# Altium schematic sheet WifiDB.SchDoc
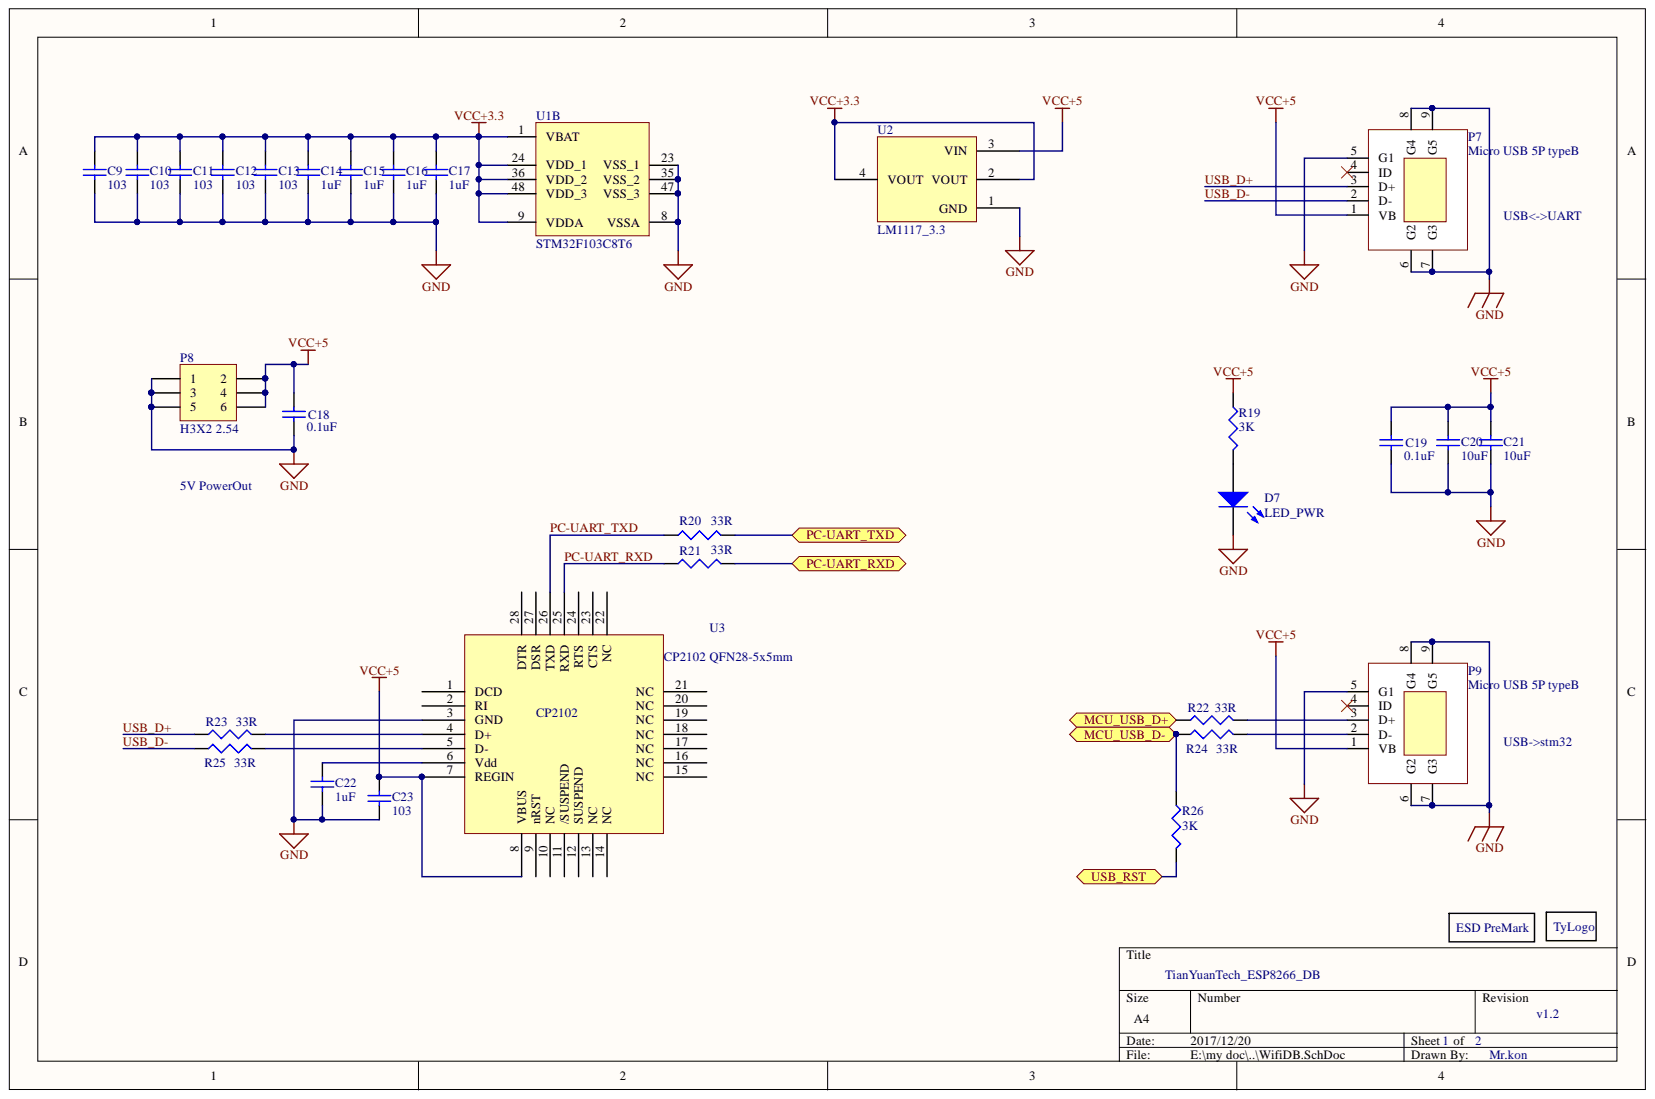
[diagram p0: WifiDB.SchDoc]

## Parts
C9  103  [1608[0603]]
C10  103  [1608[0603]]
C11  103  [1608[0603]]
C12  103  [1608[0603]]
C13  103  [1608[0603]]
C14  1uF  [1608[0603]]
C15  1uF  [1608[0603]]
C16  1uF  [1608[0603]]
C17  1uF  [1608[0603]]
C18  0.1uF  [1608[0603]]
C19  0.1uF  [1608[0603]]
C20  10uF  [1608[0603]]
C21  10uF  [1608[0603]]
C22  1uF  [1608[0603]]
C23  103  [1608[0603]]
D7  LED_PWR  [LED0805]
lo1  tylogo  [tianyuanlogo]  (value not drawn)
Logo1  ESD protect mark  [ESD_MARK]  (value not drawn)
P7  Micro USB 5P typeB  [Micro usb 5P/FB Type dip]
P8  H3X2 2.54  [HDR2X3]
P9  Micro USB 5P typeB  [Micro usb 5P/FB Type dip]
R19  value 3K  [J1-0603]  (hidden comment: Res3)
R20  value 33R  [J1-0603]  (hidden comment: Res3)
R21  value 33R  [J1-0603]  (hidden comment: Res3)
R22  value 33R  [J1-0603]  (hidden comment: Res3)
R23  value 33R  [J1-0603]  (hidden comment: Res3)
R24  value 33R  [J1-0603]  (hidden comment: Res3)
R25  value 33R  [J1-0603]  (hidden comment: Res3)
R26  value 3K  [J1-0603]  (hidden comment: Res3)
U1  STM32F103C8T6  [STM-LQFP48_N]  (part B)
U2  LM1117_3.3  [SOT230P695X180-4N]
U3  CP2102 QFN28-5x5mm  [QFN28-5x5mm]

## Ports
MCU_USB_D+, MCU_USB_D-, PC-UART_RXD, PC-UART_TXD, USB_RST

## Nets
GND: C9.1 C10.1 C11.1 C12.1 C13.1 C14.1 C15.1 C16.1 C17.1 C18.1 C19.1 C20.1 C21.1 C22.1 C23.1 D7.2 P7.5(G1) P7.6(G2) P7.7(G3) P7.8(G4) P7.9(G5) P8.1 P8.3 P8.5 P9.5(G1) P9.6(G2) P9.7(G3) P9.8(G4) P9.9(G5) U1.8(VSSA) U1.23(VSS_1) U1.35(VSS_2) U1.47(VSS_3) U2.1(GND) U3.3(GND)
VCC+3.3: C9.2 C10.2 C11.2 C12.2 C13.2 C14.2 C15.2 C16.2 C17.2 U1.1(VBAT) U1.9(VDDA) U1.24(VDD_1) U1.36(VDD_2) U1.48(VDD_3) U2.2(VOUT) U2.4(VOUT)
VCC+5: C18.2 C19.2 C20.2 C21.2 C23.2 P7.1(VB) P8.2 P8.4 P8.6 P9.1(VB) R19.1 U2.3(VIN) U3.7(REGIN) U3.8(VBUS)
PC-UART_RXD: R21.1 U3.25(RXD)
PC-UART_TXD: R20.1 U3.26(TXD)
USB_D+: P7.3(D+) R23.1
USB_D-: P7.2(D-) R25.1
USB_RST: R26.2 ; port USB_RST
NetC22_2: C22.2 U3.6(Vdd)
NetD7_1: D7.1 R19.2
NetP9_2: P9.2(D-) R24.2
NetP9_3: P9.3(D+) R22.2
NetR20_2: R20.2 ; port PC-UART_TXD
NetR21_2: R21.2 ; port PC-UART_RXD
NetR22_1: R22.1
NetR23_2: R23.2 U3.4(D+)
NetR24_1: R24.1 R26.1
NetR25_2: R25.2 U3.5(D-)

Not connected: P7 4(ID); P9 4(ID); U3 1(DCD) 2(RI) 9(nRST) 10(NC) 11(/SUSPEND) 12(SUSPEND) 13(NC) 14(NC) 15(NC) 16(NC) 17(NC) 18(NC) 19(NC) 20(NC) 21(NC) 22(NC) 23(CTS) 24(RTS) 27(DSR) 28(DTR)

## Library data of the parts
C9-C23 = C 0603/25v: Component Kind: Standard; Library Name: mysch.SchLib
D7 = LED2: GREEN; Component Kind: Standard; LatestRevisionDate: 17-Jul-2002; LatestRevisionNote: Re-released for DXP Platform.; Library Name: Miscellaneous Devices.IntLib; PackageDescription: SM LED; 2 Flat Leads; PackageReference: 3.2X1.6X1.1; PackageVersion: Feb-2002; Published: 8-Jun-2000; Simulation: LED2; Publisher: Altium Limited
Logo1 = ESD PreMark: Component Kind: Standard; Library Name: New_Integrated_Library.IntLib
P7 P9 = USB 5P/F B Type: Micro or Mini; Component Kind: Standard; Library Name: New_Integrated_Library.IntLib
P8 = Header 3X2: Header, 3-Pin, Dual row; Component Kind: Standard; LatestRevisionDate: 17-Jul-2002; LatestRevisionNote: Re-released for DXP Platform.; Library Name: Miscellaneous Connectors.IntLib; Signal Integrity: Connector; Publisher: Altium Limited
R19-R26 = Res3: Resistor; Component Kind: Standard; LatestRevisionDate: 29-May-2009; LatestRevisionNote: IPC-7351 Footprint Added.; Library Name: Miscellaneous Devices.IntLib; PackageDescription: Chip Resistor; PackageReference: J1-0603; Published: 8-Jun-2000; Signal Integrity: Res; Simulation: RESISTOR; Publisher: Altium Limited
U1 = STM32F103C8T6: ARM Cortex-M3 32-bit MCU, 64 KB Flash, 20 KB Internal RAM, 37 I/Os, 48-pin LQFP, -40 to 85 degC, Tray; A/D Converter: 2x12-bit (10 Channel); FLASH Size (Prog) (kB): 64; I/Os (High Current): 37; Internal RAM Size (kB): 20; Operating Frequency(F) (Processor speed) (MHz): 72; Supply Voltage(Vcc) min (V): 2; Component Kind: Standard; ComponentLink1Description: Manufacturer URL; ComponentLink1URL: http://www.st.com/web/en/home.html; ComponentLink2Description: Datasheet; ComponentLink2URL: http://www.st.com/st-web-ui/static/active/en/resource/technical/document/datasheet/CD00161566.pdf; ComponentLink3Description: Package Specification; ComponentLink3URL: http://www.st.com/st-web-ui/static/active/en/resource/technical/document/datasheet/DM00058181.pdf; Core: ARM Cortex-M3; DatasheetVersion: Rev. 16; Library Name: STMicroelectronics STM32 F1.IntLib; Mounting Technology: Surface Mount; PackageDescription: 48-Pin Low Profile Quad Flat Package, 7 x 7 mm Body, 0.5 mm Pitch; PackageReference: LQFP48; PackageVersion: Rev. 6, 06/2013; Packing: Tray; PartNumber: STM32F103C8T6; Supplier 1: Digi-Key; Supplier 2: Farnell; Supplier 3: Newark; Supplier 4: Newark; Supplier 5: Mouser; Supplier Part Number 1: 497-6063-ND; Supplier Part Number 2: 1447637; Supplier Part Number 3: 59M2182; Supplier Part Number 4: 57P1222; Supplier Part Number 5: 511-STM32F103C8T6
U3 = CP2102: Component Kind: Standard; Library Name: New_Integrated_Library.IntLib
lo1 = tylogo: Component Kind: Standard; Library Name: New_Integrated_Library.IntLib
U2 = LM1117_3.3: Component Kind: Standard; Library Name: mysch.SchLib
